# Altium schematic sheet control_unit.SchDoc(control_unit)
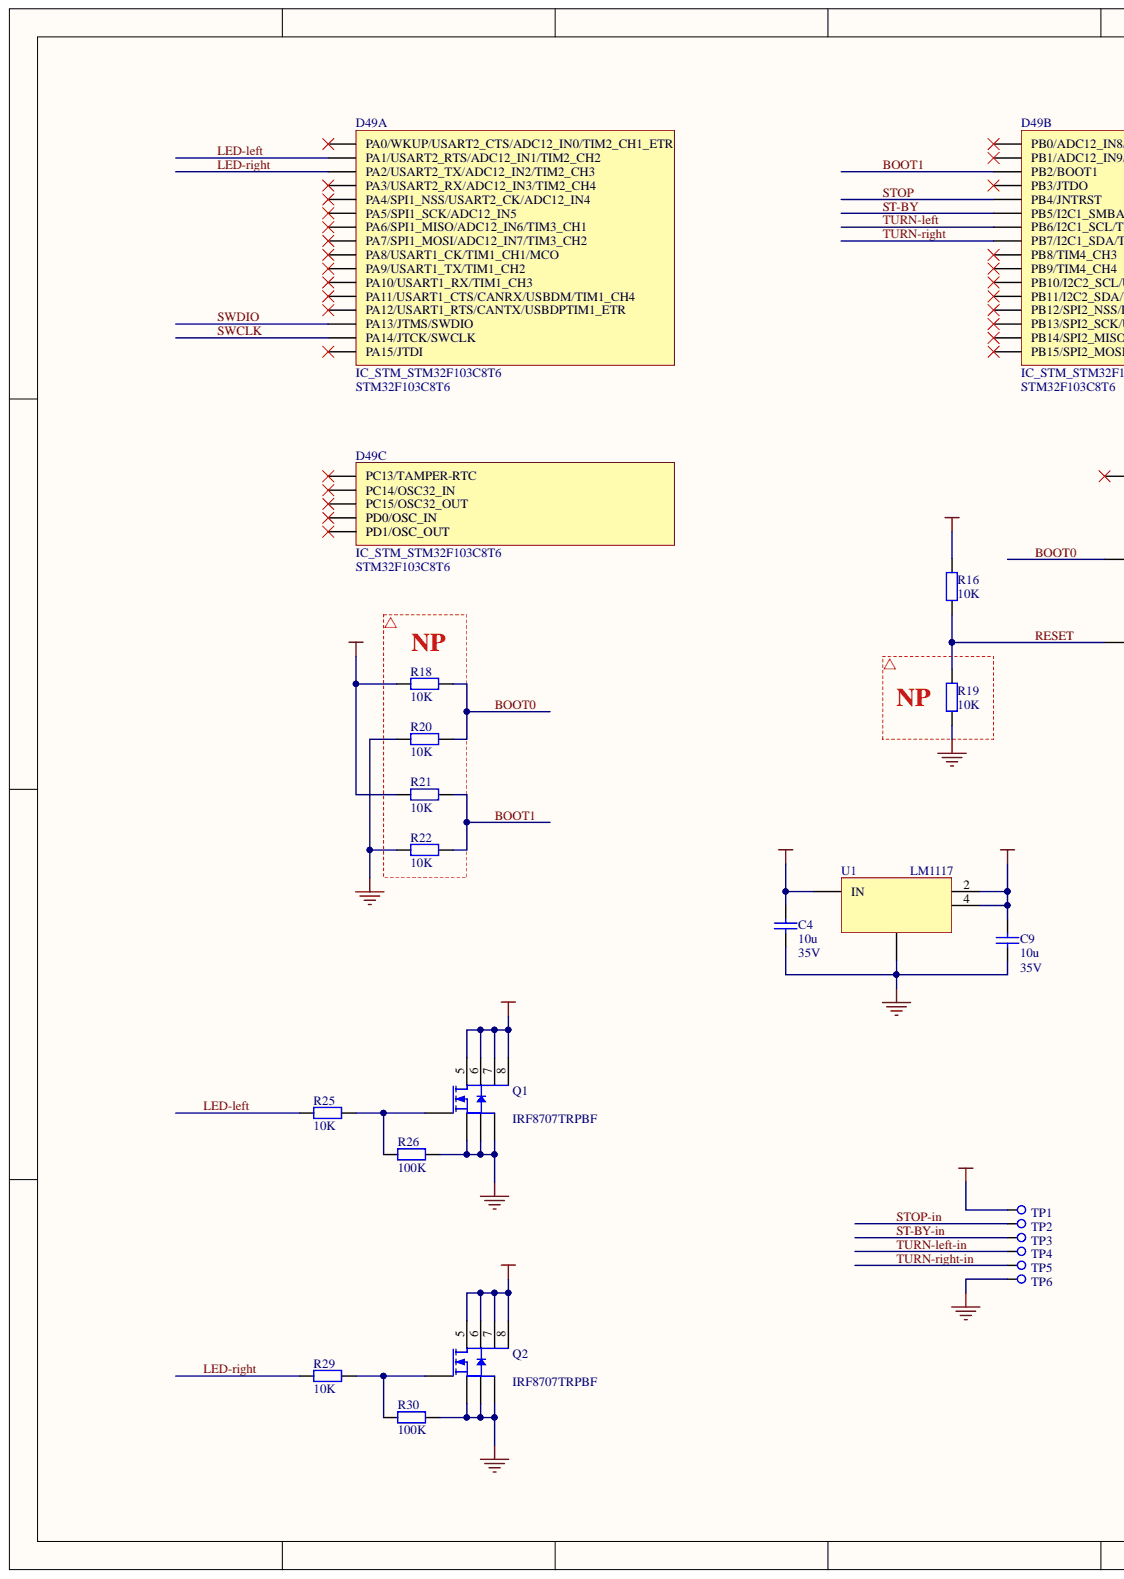
[diagram p0: control_unit.SchDoc, left]
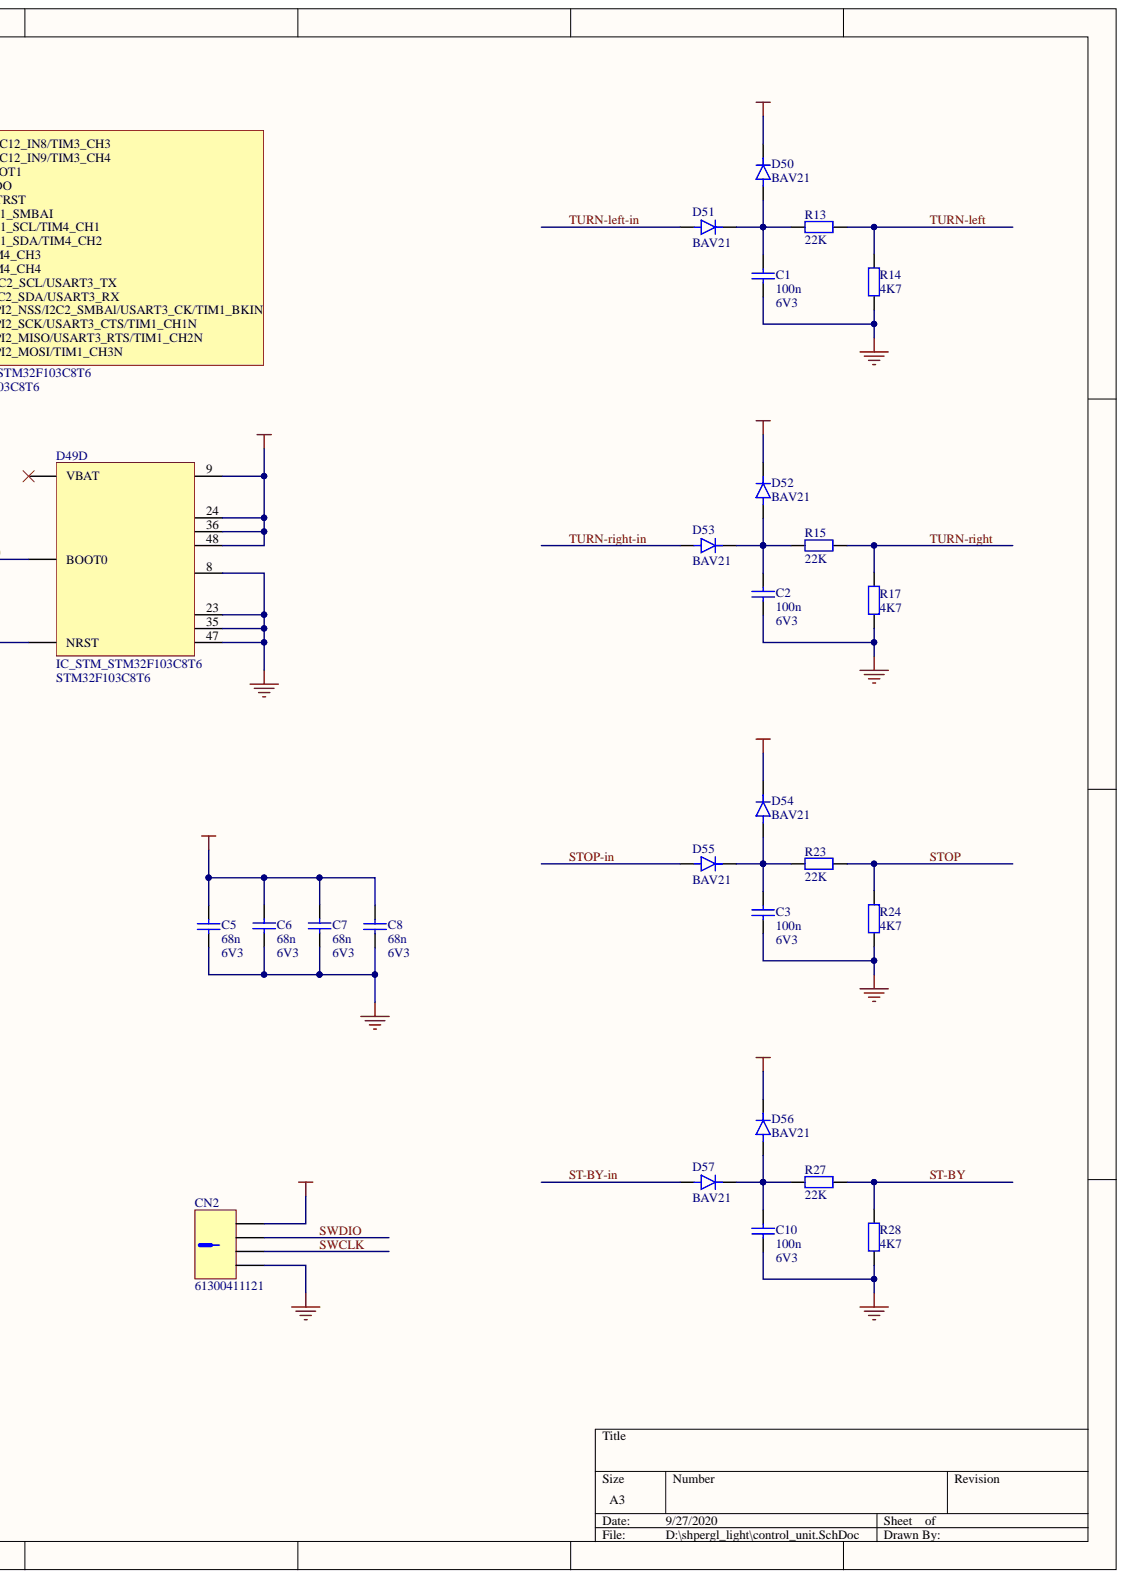
[diagram p1: control_unit.SchDoc, right]

## Parts
C1  Value2: 6V3  Value1: 100n  [CAPC2012X88N]  (hidden comment: CAP)
C2  Value2: 6V3  Value1: 100n  [CAPC2012X88N]  (hidden comment: CAP)
C3  Value2: 6V3  Value1: 100n  [CAPC2012X88N]  (hidden comment: CAP)
C4  Value2: 35V  Value1: 10u  [CAPC3216X18N]  (hidden comment: CAP)
C5  Value2: 6V3  Value1: 68n  [CAPC2012X100N]  (hidden comment: CAP)
C6  Value2: 6V3  Value1: 68n  [CAPC2012X100N]  (hidden comment: CAP)
C7  Value2: 6V3  Value1: 68n  [CAPC2012X100N]  (hidden comment: CAP)
C8  Value2: 6V3  Value1: 68n  [CAPC2012X100N]  (hidden comment: CAP)
C9  Value2: 35V  Value1: 10u  [CAPC3216X18N]  (hidden comment: CAP)
C10  Value2: 6V3  Value1: 100n  [CAPC2012X88N]  (hidden comment: CAP)
CN2  Value1: 61300411121  [CN_WURTH_61300411121]  (hidden comment: CN_1X4M)
D49  IC_STM_STM32F103C8T6  Value1: STM32F103C8T6  [TSQFP50P900X900X160-48N]  (parts A,B,C,D)
D50  Value1: BAV21  [SODFL370X136-2M]  (hidden comment: DIO)
D51  Value1: BAV21  [SODFL370X136-2M]  (hidden comment: DIO)
D52  Value1: BAV21  [SODFL370X136-2M]  (hidden comment: DIO)
D53  Value1: BAV21  [SODFL370X136-2M]  (hidden comment: DIO)
D54  Value1: BAV21  [SODFL370X136-2M]  (hidden comment: DIO)
D55  Value1: BAV21  [SODFL370X136-2M]  (hidden comment: DIO)
D56  Value1: BAV21  [SODFL370X136-2M]  (hidden comment: DIO)
D57  Value1: BAV21  [SODFL370X136-2M]  (hidden comment: DIO)
Q1  Value1: IRF8707TRPBF  [SOIC127P600X175-8N]  (hidden comment: TRS_INFINEON_IRF8707TRPBF)
Q2  Value1: IRF8707TRPBF  [SOIC127P600X175-8N]  (hidden comment: TRS_INFINEON_IRF8707TRPBF)
R13  Value1: 22K  [RESC2012X60N]  (hidden comment: RES)
R14  Value1: 4K7  [RESC2012X60N]  (hidden comment: RES)
R15  Value1: 22K  [RESC2012X60N]  (hidden comment: RES)
R16  Value1: 10K  [RESC2012X60N]  (hidden comment: RES)
R17  Value1: 4K7  [RESC2012X60N]  (hidden comment: RES)
R18  Value1: 10K  [RESC2012X60N]  (hidden comment: RES)
R19  Value1: 10K  [RESC2012X60N]  (hidden comment: RES)
R20  Value1: 10K  [RESC2012X60N]  (hidden comment: RES)
R21  Value1: 10K  [RESC2012X60N]  (hidden comment: RES)
R22  Value1: 10K  [RESC2012X60N]  (hidden comment: RES)
R23  Value1: 22K  [RESC2012X60N]  (hidden comment: RES)
R24  Value1: 4K7  [RESC2012X60N]  (hidden comment: RES)
R25  Value1: 10K  [RESC2012X60N]  (hidden comment: RES)
R26  Value1: 100K  [RESC2012X60N]  (hidden comment: RES)
R27  Value1: 22K  [RESC2012X60N]  (hidden comment: RES)
R28  Value1: 4K7  [RESC2012X60N]  (hidden comment: RES)
R29  Value1: 10K  [RESC2012X60N]  (hidden comment: RES)
R30  Value1: 100K  [RESC2012X60N]  (hidden comment: RES)
TP1  MSC_TP  [MSC_TP_THT1MM]  (value not drawn)  (no BOM)
TP2  MSC_TP  [MSC_TP_THT1MM]  (value not drawn)  (no BOM)
TP3  MSC_TP  [MSC_TP_THT1MM]  (value not drawn)  (no BOM)
TP4  MSC_TP  [MSC_TP_THT1MM]  (value not drawn)  (no BOM)
TP5  MSC_TP  [MSC_TP_THT1MM]  (value not drawn)  (no BOM)
TP6  MSC_TP  [MSC_TP_THT1MM]  (value not drawn)  (no BOM)
U1  Value1: LM1117  [IC_TI_LM1117]  (hidden comment: IC_TI_LM1117)

## Nets
3V3: C5.2 C6.2 C7.2 C8.2 C9.2 CN2.1 D49.9 D49.24 D49.36 D49.48 R16.2 R18.1 R21.1 U1.2 U1.4
12V: C4.2 D50.1 D52.1 D54.1 D56.1 TP1.1 U1.3(IN)
GND: C1.1 C2.1 C3.1 C4.1 C5.1 C6.1 C7.1 C8.1 C9.1 C10.1 CN2.4 D49.8 D49.23 D49.35 D49.47 Q1.1 Q1.2 Q1.3 Q2.1 Q2.2 Q2.3 R14.1 R17.1 R19.1 R20.1 R22.1 R24.1 R26.2 R28.1 R30.2 TP6.1 U1.1
VCC_LEFT: Q1.5 Q1.6 Q1.7 Q1.8
VCC_RIGHT: Q2.5 Q2.6 Q2.7 Q2.8
BOOT0: D49.44(BOOT0) R18.2 R20.2
BOOT1: D49.20(PB2/BOOT1) R21.2 R22.2
LED-left: D49.11(PA1/USART2_RTS/ADC12_IN1/TIM2_CH2) R25.2
LED-right: D49.12(PA2/USART2_TX/ADC12_IN2/TIM2_CH3) R29.2
RESET: D49.7(NRST) R16.1 R19.2
ST-BY: D49.41(PB5/I2C1_SMBAI) R27.2 R28.2
ST-BY-in: D57.2 TP3.1
STOP: D49.40(PB4/JNTRST) R23.2 R24.2
STOP-in: D55.2 TP2.1
SWCLK: CN2.3 D49.37(PA14/JTCK/SWCLK)
SWDIO: CN2.2 D49.34(PA13/JTMS/SWDIO)
TURN-left: D49.42(PB6/I2C1_SCL/TIM4_CH1) R13.2 R14.2
TURN-left-in: D51.2 TP4.1
TURN-right: D49.43(PB7/I2C1_SDA/TIM4_CH2) R15.2 R17.2
TURN-right-in: D53.2 TP5.1
NetC1_2: C1.2 D50.2 D51.1 R13.1
NetC2_2: C2.2 D52.2 D53.1 R15.1
NetC3_2: C3.2 D54.2 D55.1 R23.1
NetC10_2: C10.2 D56.2 D57.1 R27.1
NetQ1_4: Q1.4 R25.1 R26.1
NetQ2_4: Q2.4 R29.1 R30.1

Not connected: D49 1(VBAT) 2(PC13/TAMPER-RTC) 3(PC14/OSC32_IN) 4(PC15/OSC32_OUT) 5(PD0/OSC_IN) 6(PD1/OSC_OUT) 10(PA0/WKUP/USART2_CTS/ADC12_IN0/TIM2_CH1_ETR) 13(PA3/USART2_RX/ADC12_IN3/TIM2_CH4) 14(PA4/SPI1_NSS/USART2_CK/ADC12_IN4) 15(PA5/SPI1_SCK/ADC12_IN5) 16(PA6/SPI1_MISO/ADC12_IN6/TIM3_CH1) 17(PA7/SPI1_MOSI/ADC12_IN7/TIM3_CH2) 18(PB0/ADC12_IN8/TIM3_CH3) 19(PB1/ADC12_IN9/TIM3_CH4) 21(PB10/I2C2_SCL/USART3_TX) 22(PB11/I2C2_SDA/USART3_RX) 25(PB12/SPI2_NSS/I2C2_SMBAl/USART3_CK/TIM1_BKIN) 26(PB13/SPI2_SCK/USART3_CTS/TIM1_CH1N) 27(PB14/SPI2_MISO/USART3_RTS/TIM1_CH2N) 28(PB15/SPI2_MOSI/TIM1_CH3N) 29(PA8/USART1_CK/TIM1_CH1/MCO) 30(PA9/USART1_TX/TIM1_CH2) 31(PA10/USART1_RX/TIM1_CH3) 32(PA11/USART1_CTS/CANRX/USBDM/TIM1_CH4) 33(PA12/USART1_RTS/CANTX/USBDPTIM1_ETR) 38(PA15/JTDI) 39(PB3/JTDO) 45(PB8/TIM4_CH3) 46(PB9/TIM4_CH4)

## Library data of the parts
C1-C3 C10 = 01_00637: X7R 10% 0805; Manufacturer PN: C0805C104K9RACTU; Manufacturer: KEMET; SubType: Ceramic; DeviceType: capacitor; AutoId: 637; Supplier Part Number 1: 80-C0805C104K9R; Supplier 1: Farnell; Status: 2 - Checked and Approved; MountType: SMT; Id: 01_00637; Database Table Name: CAP; ComponentLink1Description: Datasheet; Component Kind: Standard; Library Name: DSW_Lib.DbLib; ComponentLink1URL: X:\01_Datasheets\CAP_KEMET_CxxxxCxxxXxRAC.pdf
C4 C9 = 01_01484: MURATA - GRM31CR6YA106KA12L - CAP, MLCC, X5R, 10UF, 35V, 1206; SubType: Ceramic; DeviceType: capacitor; AutoId: 1484; Supplier Part Number 1: 1797011; Supplier 1: Farnell; Status: 2 - Checked and Approved; MountType: SMD; Manufacturer PN: GRM31CR6YA106KA12L; Manufacturer: Murata; Id: 01_01484; Database Table Name: CAP; ComponentLink1Description: Datasheet; Component Kind: Standard; Library Name: DSW_Lib.DbLib; ComponentLink1URL: X:\01_dataSheets\CAP_MURATA_GRM31CR6YA106KA12L.pdf
C5-C8 = 01_00635: X7R 10% 0805; Manufacturer PN: C0805C683K9RACTU; Manufacturer: KEMET; SubType: Ceramic; DeviceType: capacitor; AutoId: 635; Supplier 1: Farnell; Status: 2 - Checked and Approved; MountType: SMT; Id: 01_00635; Database Table Name: CAP; ComponentLink1Description: Datasheet; Component Kind: Standard; Library Name: DSW_Lib.DbLib; ComponentLink1URL: X:\01_Datasheets\CAP_KEMET_CxxxxCxxxXxRAC.pdf
CN2 = 02_00034: 4 pin male pin header, pitch 2.54mm; Manufacturer: Wurth Electronics; Value3: 4 pin; SubType: 1x4; DeviceType: Connector_4; Database Table Name: CN; AutoId: 36; Supplier Part Number 1: 61300411121; Supplier 1: Wurth; Status: 2 - Checked and Approved; MountType: THT; Manufacturer PN: 61300411121; Id: 02_00034; ComponentLink2Description: ComponentVerificationSheet; ComponentLink1Description: Datasheet; Component Kind: Standard; Library Name: DSW_Lib.DbLib; ComponentLink2URL: X:\02_ComponentVerificationSheets\CN_WURTH_61300411121_ComponentVerificationSheet.xlsx; ComponentLink1URL: X:\01_Datasheets\CN_WURTH_6130xx11121.pdf
D49 = 07_00285: MCU 32BIT Cortex M3 64KB 20KB RAM 2X12 ADC; Manufacturer: STM; Value3: ARM 32bit; Value2: Microcontroller; DeviceType: IC; AutoId: 292; Supplier Part Number 1: 511-STM32F103C8T6; Supplier 1: Mouser; Status: 0 - Unchecked; MountType: SMT; Manufacturer PN: STM32F103C8T6; Id: 07_00285; Database Table Name: IC; ComponentLink2Description: ComponentVerificationSheet; ComponentLink1Description: Datasheet; Component Kind: Standard; Library Name: DSW_Lib.DbLib; ComponentLink2URL: X:\02_ComponentVerificationSheets\; ComponentLink1URL: X:\01_Datasheets\IC_STM_STM32F103C8T6.pdf
D50-D57 = 03_00081: Diodes - General Purpose, Power, Switching 250V 625mA 1A IFSM; Manufacturer PN: BAV21W-E3-08; Manufacturer: Vishay; Value3: Diode; Value2: 250V 250mA; AutoId: 91; Supplier Part Number 1: 78-BAV21W-E3-08; Supplier 1: Mouser; SubType: general; Status: 0 - Unchecked; MountType: SMD; Id: 03_00081; DeviceType: diode; Database Table Name: DIO; ComponentLink2Description: ComponentVerificationSheet; ComponentLink1Description: Datasheet; Component Kind: Standard; Library Name: DSW_Lib.DbLib; ComponentLink2URL: X:\02_ComponentVerificationSheets\; ComponentLink1URL: X:\01_Datasheets\DIO_Vishay_BAV21.pdf
Q1 Q2 = 19_00042: MOSFET MOSFT 30V 11A 11.9mOhm 6.2nC Qg; Value2: 30V 11A; SubType: N MOSFET; DeviceType: transistor; Database Table Name: TRS; AutoId: 48; Supplier Part Number 1: 942-IRF8707TRPBF; Supplier 1: Mouser; Status: 0 - Unchecked; MountType: SMT; Manufacturer PN: IRF8707TRPBF; Manufacturer: Infineon; Id: 19_00042; ComponentLink2Description: ComponentVerificationSheet; ComponentLink1Description: Datasheet; Component Kind: Standard; Library Name: DSW_Lib.DbLib; ComponentLink2URL: X:\02_ComponentVerificationSheets\; ComponentLink1URL: X:\01_Datasheets\TRS_INFINEON_IRF8707TRPBF.pdf
R13 R15 R23 R27 = 15_00345: RESISTOR, 0805, 22K, 1%, SMD; Manufacturer PN: RC0805FR-0722K; Manufacturer: Yageo; Value2: 0W125; SubType: Metal film; AutoId: 345; Supplier 1: Farnell; Status: 0 - Unchecked; MountType: SMT; Id: 15_00345; DeviceType: resistor; Database Table Name: RES; ComponentLink1Description: Datasheet; Component Kind: Standard; Library Name: DSW_Lib.DbLib; ComponentLink1URL: X:\01_Datasheets\RES_YAGEO_RC0805FR.pdf
R14 R17 R24 R28 = 15_00337: RESISTOR, 0805, 4K7, 1%, SMD; Manufacturer PN: RC0805FR-074K7; Manufacturer: Yageo; Value2: 0W125; SubType: Metal film; AutoId: 337; Supplier Part Number 1: 9237704; Supplier 1: Farnell; Status: 2 - Checked and Approved; MountType: SMT; Id: 15_00337; DeviceType: resistor; Database Table Name: RES; ComponentLink1Description: Datasheet; Component Kind: Standard; Library Name: DSW_Lib.DbLib; ComponentLink1URL: X:\01_Datasheets\RES_YAGEO_RC0805FR.pdf
R16 R18-R22 R25 R29 = 15_00341: RESISTOR, 0805, 10K, 1%, SMD; Manufacturer PN: RC0805FR-0710K; Manufacturer: Yageo; Value2: 0W125; SubType: Metal film; AutoId: 341; Supplier 1: Farnell; Status: 0 - Unchecked; MountType: SMT; Id: 15_00341; DeviceType: resistor; Database Table Name: RES; ComponentLink1Description: Datasheet; Component Kind: Standard; Library Name: DSW_Lib.DbLib; ComponentLink1URL: X:\01_Datasheets\RES_YAGEO_RC0805FR.pdf
R26 R30 = 15_00353: RESISTOR, 0805, 100K, 1%, SMD; Manufacturer PN: RC0805FR-07100K; Manufacturer: Yageo; Value2: 0W125; SubType: Metal film; AutoId: 353; Supplier 1: Farnell; Status: 0 - Unchecked; MountType: SMT; Id: 15_00353; DeviceType: resistor; Database Table Name: RES; ComponentLink1Description: Datasheet; Component Kind: Standard; Library Name: DSW_Lib.DbLib; ComponentLink1URL: X:\01_Datasheets\RES_YAGEO_RC0805FR.pdf
TP1-TP6 = 12_00001: With Hole for 1 header pin; Value2: NO BUY; Value1: TESTPOINT_TH; Database Table Name: MSC; AutoId: 2; Status: 0 - Unchecked; MountType: THT; Id: 12_00001; ComponentLink2Description: ComponentVerificationSheet; ComponentLink1Description: Datasheet; Library Name: DSW_Lib.DbLib; ComponentLink2URL: X:\02_ComponentVerificationSheets\; ComponentLink1URL: X:\01_Datasheets\
U1 = 07_00244: LDO Voltage Regulators 800MA LDO LINEAR REG; Manufacturer PN: LM1117MPX-3.3/NOPB; Manufacturer: TI; Value3: 3V3; Database Table Name: IC; AutoId: 242; Value2: LDO; Supplier Part Number 1: 926-LM1117MPX3.3NOPB; Supplier 1: Mouser; Status: 0 - Unchecked; MountType: SMT; Id: 07_00244; DeviceType: LDO; ComponentLink2Description: ComponentVerificationSheet; ComponentLink1Description: Datasheet; Component Kind: Standard; Library Name: DSW_Lib.DbLib; ComponentLink2URL: X:\02_ComponentVerificationSheets\; ComponentLink1URL: X:\01_Datasheets\IC_TI_LM1117.pdf
